# Altium schematic sheet 控制板.SchDoc
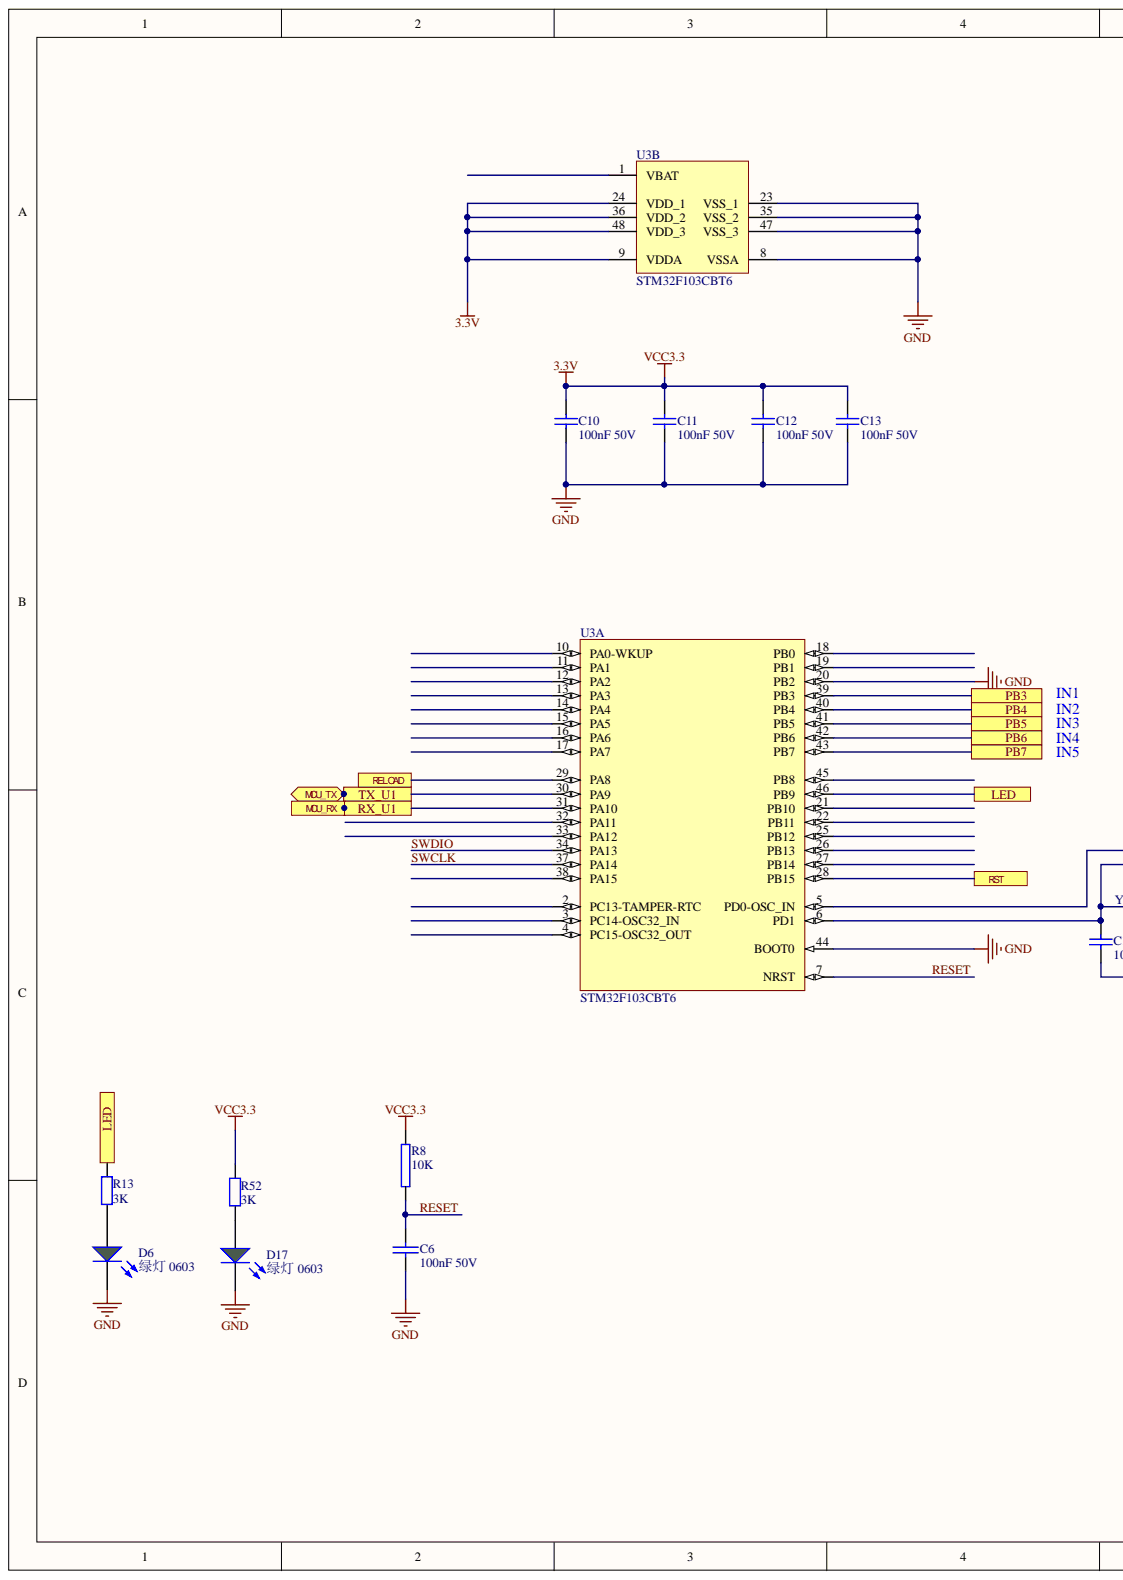
[diagram p0: 控制板.SchDoc, left]
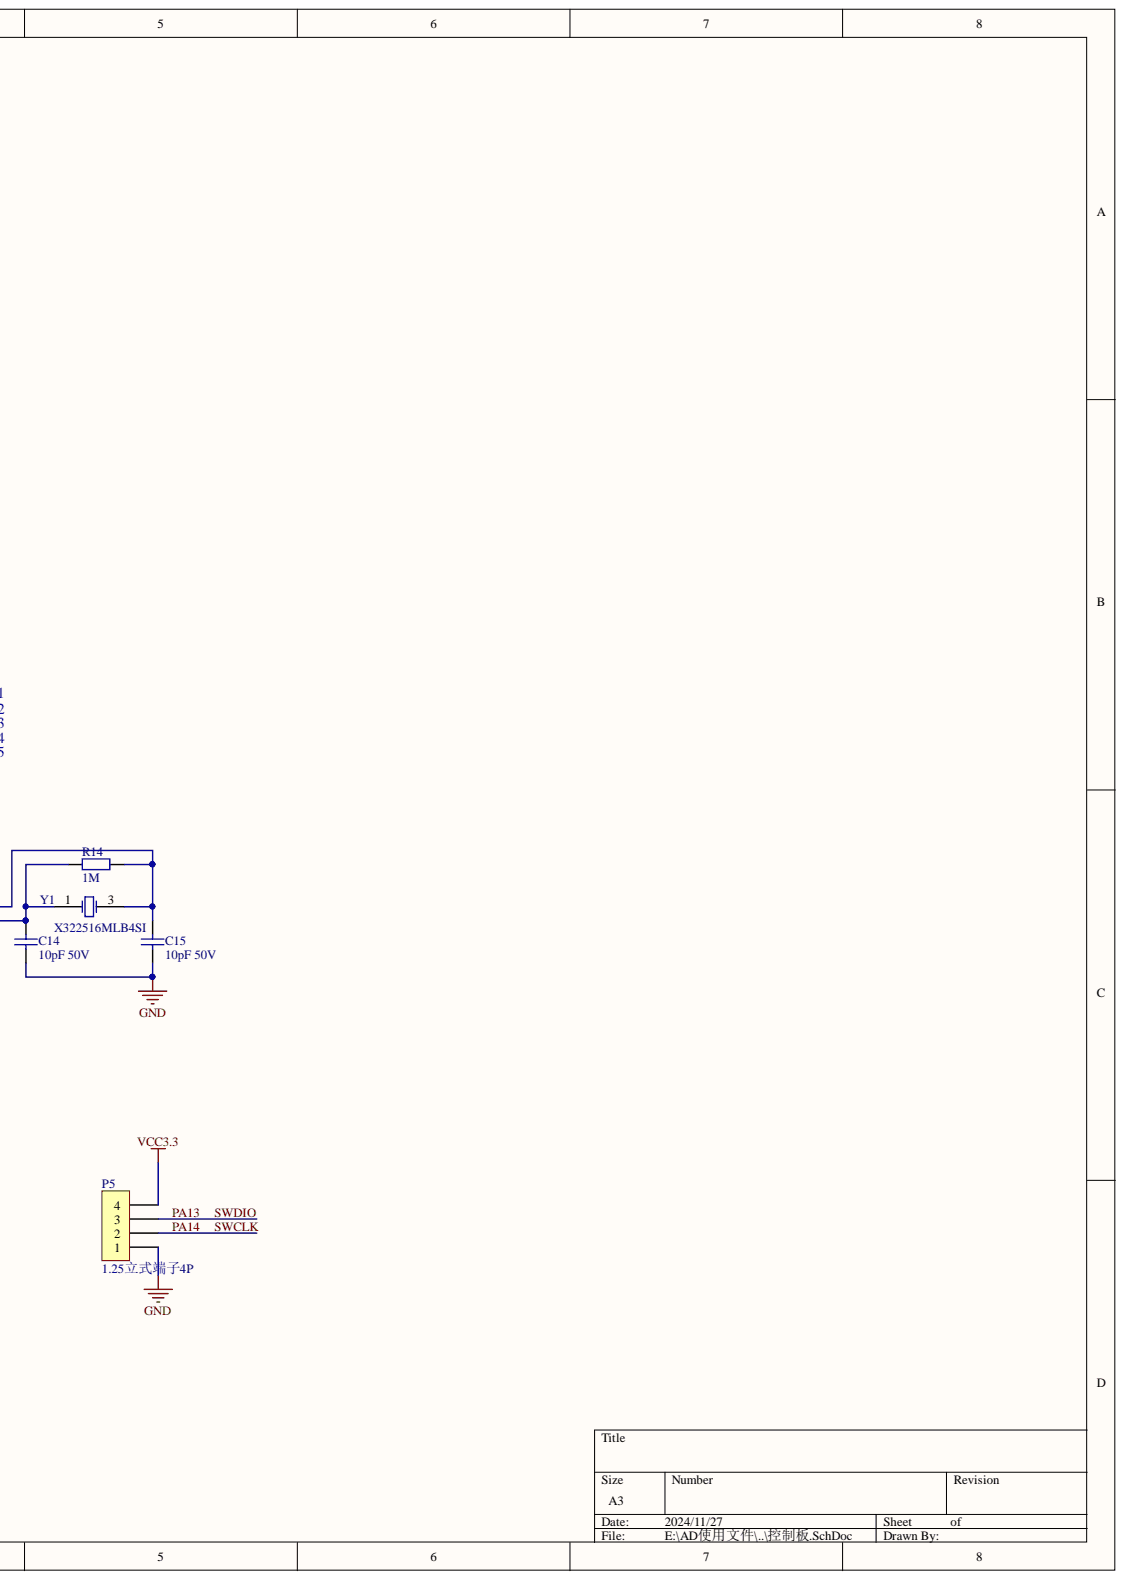
[diagram p1: 控制板.SchDoc, right]

## Parts
C6  100nF 50V  [0603_C]
C10  100nF 50V  [0603_C]
C11  100nF 50V  [0603_C]
C12  100nF 50V  [0603_C]
C13  100nF 50V  [0603_C]
C14  10pF 50V  [0603_C]
C15  10pF 50V  [0603_C]
D6  绿灯 0603  [0603_LED_S1]
D17  绿灯 0603  Package: 0603  [0603_LED_S1]
P5  1.25立式端子4P  [1.2.5/4PM]
R8  10K  [0603_R]
R13  3K  [0603_R]
R14  1M  [0603_R]
R52  3K  [0603_R]
U3  STM32F103CBT6  [LQFP-48_7x7x05P]  (parts A,B)
Y1  X322516MLB4SI  [SMD-3225_4P]

## Ports
LED, MCU_RX, MCU_TX, PB3, PB4, PB5, PB6, PB7, RELOAD, RST, RX_U1, TX_U1

## Nets
3.3V: C10.2 C11.2 C12.2 C13.2 P5.4 R8.2 R52.2 U3.9(VDDA) U3.24(VDD_1) U3.36(VDD_2) U3.48(VDD_3)
GND: C6.2 C10.1 C11.1 C12.1 C13.1 C14.1 C15.1 D6.K D17.K P5.1 U3.8(VSSA) U3.20(PB2) U3.23(VSS_1) U3.35(VSS_2) U3.44(BOOT0) U3.47(VSS_3)
LED: R13.2 U3.46(PB9) ; port LED
MCU_RX: U3.31(PA10) ; port MCU_RX, port RX_U1
MCU_TX: U3.30(PA9) ; port MCU_TX, port TX_U1
PA13: P5.3 U3.34(PA13)
PA14: P5.2 U3.37(PA14)
PB3: U3.39(PB3) ; port PB3
PB4: U3.40(PB4) ; port PB4
PB5: U3.41(PB5) ; port PB5
PB6: U3.42(PB6) ; port PB6
PB7: U3.43(PB7) ; port PB7
RELOAD: U3.29(PA8) ; port RELOAD
RESET: C6.1 R8.1 U3.7(NRST)
RST: U3.28(PB15) ; port RST
NetC14_2: C14.2 R14.1 U3.6(PD1) Y1.1
NetC15_2: C15.2 R14.2 U3.5(PD0-OSC_IN) Y1.3
NetD6_A: D6.A R13.1
NetD17_A: D17.A R52.1

Not connected: U3 1(VBAT) 2(PC13-TAMPER-RTC) 3(PC14-OSC32_IN) 4(PC15-OSC32_OUT) 10(PA0-WKUP) 11(PA1) 12(PA2) 13(PA3) 14(PA4) 15(PA5) 16(PA6) 17(PA7) 18(PB0) 19(PB1) 21(PB10) 22(PB11) 25(PB12) 26(PB13) 27(PB14) 32(PA11) 33(PA12) 38(PA15) 45(PB8)

## Library data of the parts
C6 = CAP: Component Kind: Standard; Library Name: wgh元件库.SCHLIB
C10-C13 = 0402 100nF (104) 10% 16V: 贴片电容; Component Kind: Standard; ComponentLink1Description: 供应商链接; ComponentLink1URL: http://www.szlcsc.com/product/details_1877.html; Library Name: Cap.SchLib; Package: 0402; Supplier: LC; SuppliersPartNumber: C1525
C14 C15 = 0402 10pF (100) 5% 50V: 贴片电容; Component Kind: Standard; ComponentLink1Description: 供应商链接; ComponentLink1URL: http://www.szlcsc.com/product/details_33914.html; Library Name: Cap.SchLib; Package: 0402; Supplier: LC; SuppliersPartNumber: C32949
D6 D17 = 0603 绿灯: 发光二极管; Component Kind: Standard; ComponentLink1Description: 供应商链接; ComponentLink1URL: http://www.szlcsc.com/product/details_73152.html; Library Name: LED.SchLib; Supplier: LC; SuppliersPartNumber: C72043
  D6: Package: 0603
P5 = CON4: Component Kind: Standard; Library Name: wgh元件库.SCHLIB
R8 = RES: Component Kind: Standard; Library Name: wgh元件库.SCHLIB
R13 R52 = 0603 20KΩ (2002) 1%: 贴片电阻; Component Kind: Standard; ComponentLink1Description: 供应商链接; ComponentLink1URL: http://www.szlcsc.com/product/details_4591.html; Library Name: RES.SchLib; Package: 0603; Supplier: LC; SuppliersPartNumber: C4184
R14 = 0402 1MΩ (1004) 1%: 贴片电阻; Component Kind: Standard; ComponentLink1Description: 供应商链接; ComponentLink1URL: http://www.szlcsc.com/product/details_26826.html; Library Name: RES.SchLib; Package: 0402; Supplier: LC; SuppliersPartNumber: C26083
U3 = STM32F103CBT6: ARM; Component Kind: Standard; ComponentLink1Description: 供应商链接; ComponentLink1URL: http://www.szlcsc.com/product/details_8796.html; Library Name: MCU.SchLib; Package: LQFP-48_7x7x05P; Supplier: LC; SuppliersPartNumber: C8304
Y1 = SMD-3225_4P  16MHZ 9PF 10PPM: 16MHZ晶振; Component Kind: Standard; ComponentLink1Description: 供应商链接; ComponentLink1URL: http://www.szlcsc.com/product/details_14393.html; Library Name: CrystalOscillator.SchLib; Package: SMD-3225_4P; Supplier: LC; SuppliersPartNumber: C13738
